# Altium schematic sheet USB_and_FTDI.SchDoc
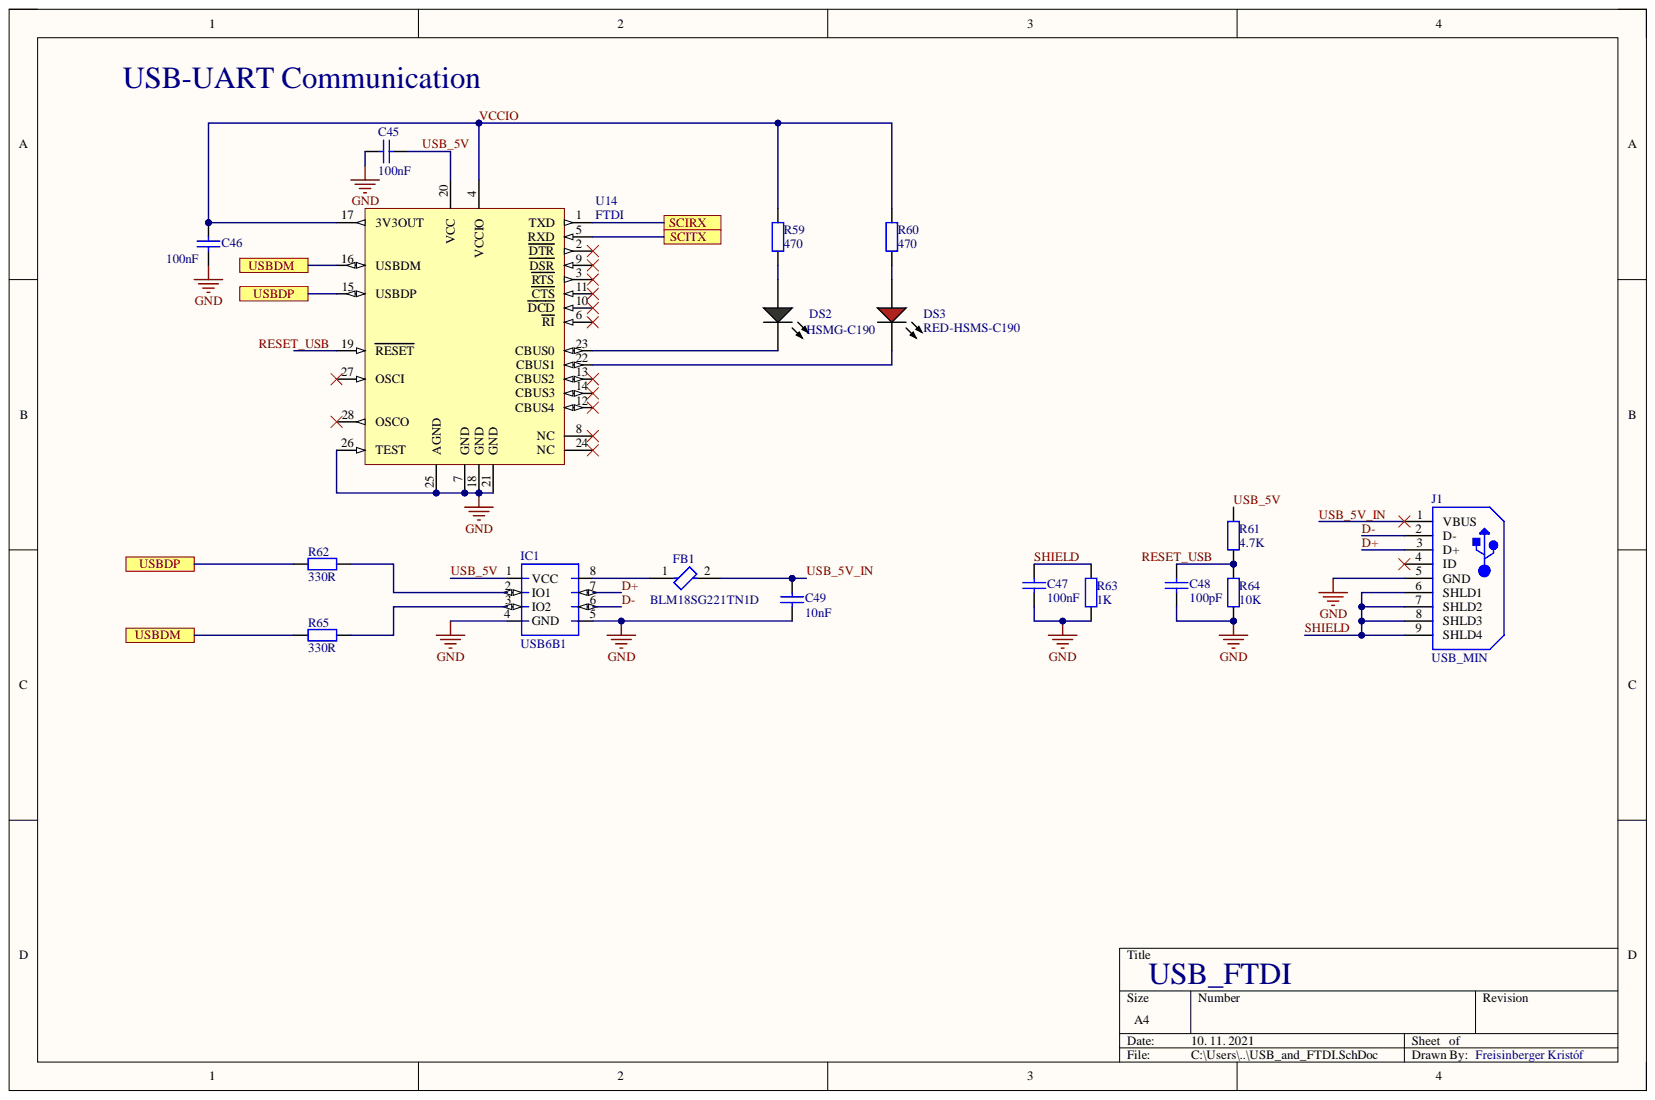
[diagram p0: USB_and_FTDI.SchDoc]

## Parts
C45  100nF  [FP_0805_CAP_085]
C46  100nF  [FP_0805_CAP_085]
C47  100nF  [FP_0805_CAP_085]
C48  100pF  [FP_0805_CAP_085]
C49  10nF  [FP_0805_CAP_085]
DS2  HSMG-C190  [AVAG-HSMX-C190_V]
DS3  RED-HSMS-C190  [AVAG-HSMX-C190_V]
FB1  BLM18SG221TN1D  [FP-BLM18-0_15-t0_5-IPC_A]
IC1  USB6B1  [INF-P-DSO-8-3_M - duplicate1]
J1  USB_MIN  [FCI-10033526-N3212LF_V]
R59  470  [FP_0603_RES]
R60  470  [FP_0603_RES]
R61  4.7K  [FP_0603_RES]
R62  330R  [FP_0603_RES]
R63  1K  [FP_0603_RES]
R64  10K  [FP_0603_RES]
R65  330R  [FP_0603_RES]
U14  FTDI  [SSOP-28_N]

## Ports
SCIRX, SCITX, USBDM, USBDP

## Nets
GND: C45.1 C46.1 C47.1 C48.1 C49.1 IC1.4(GND) IC1.5 J1.5(GND) R63.1 R64.1 U14.7(GND) U14.18(GND) U14.21(GND) U14.25(AGND) U14.26(TEST)
D+: IC1.7 J1.3(D+)
D-: IC1.6 J1.2(D-)
RESET_USB: C48.2 R61.1 R64.2 U14.19(RESET)
SCIRX: U14.1(TXD) ; port SCIRX
SCITX: U14.5(RXD) ; port SCITX
SHIELD: C47.2 J1.6(SHLD1) J1.7(SHLD2) J1.8(SHLD3) J1.9(SHLD4) R63.2
USB_5V: C45.2 IC1.1(VCC) R61.2 U14.20(VCC)
USB_5V_IN: C49.2 FB1.2 J1.1(VBUS)
USBDM: R65.1 U14.16(USBDM) ; port USBDM
USBDP: R62.1 U14.15(USBDP) ; port USBDP
VCCIO: C46.2 R59.2 R60.2 U14.4(VCCIO) U14.17(3V3OUT)
NetDS2_1: DS2.1 R59.1
NetDS2_2: DS2.2 U14.23(CBUS0)
NetDS3_1: DS3.1 R60.1
NetDS3_2: DS3.2 U14.22(CBUS1)
NetFB1_1: FB1.1 IC1.8
NetIC1_2: IC1.2(IO1) R62.2
NetIC1_3: IC1.3(IO2) R65.2

Not connected: J1 4(ID); U14 2(DTR) 3(RTS) 6(RI) 8(NC) 9(DSR) 10(DCD) 11(CTS) 12(CBUS4) 13(CBUS2) 14(CBUS3) 24(NC) 27(OSCI) 28(OSCO)

## Library data of the parts
C45-C49 = CAP: Capacitor; Component Kind: Standard; Library Name: DCDC_Converter_4_Channel.SchLib
  C45-C47: Value: 100nF
  C48: Value: 100pF
  C49: Value: 10nF
DS2 = LED_G_CMP-1744-00002-1: Chip LED, Green, 2.2 V, -40 to 85 degC, 2-Pin SMD, RoHS, Tape and Reel; Access Time: 3500 ns; Case\Package: SOIC; Clock Frequency: 100 KHz; Density: 32 kb; Frequency: 400 KHz; Interface: Serial; Max Supply Voltage: 5.5 V; Min Supply Voltage: 1.7 V; Nominal Supply Current: 2 mA; Component Kind: Standard; ComponentLink1Description: Manufacturer URL; ComponentLink1URL: http://www.avagotech.com/; ComponentLink2Description: Datasheet; ComponentLink2URL: http://docs.avagotech.com/docs/AV02-0551EN; ComponentLink3Description: Package Specification; ComponentLink3URL: http://www.fairchildsemi.com/dwg/MA/MA03D.pdf; DatasheetVersion: Mar-12; Height: 1.5 mm; Length: 5 mm; Library Name: DCDC_Converter_4_Channel.SchLib; Max Clock Frequency: 100 KHz; Max Operating Temperature: 85 degC; Min Operating Temperature: -40 degC; Mount: Surface Mount; Package Quantity: 3000; PackageDescription: 2-Pin Surface Mount Device, Body 1.6 x 0.8 mm, Height 0.9 mm; PackageReference: HSMX-C190; Packaging: Tape and Reel; Pins: 8; Radiation Hardening: No; REACH SVHC: No SVHC; RoHSCompliant: true; Width: 4 mm
DS3 = RED-CMP-2000-06427-1: LED Uni-Color Red, 52 mW, 20 mA, -40 to 85 degC, 2-Pin SMD, RoHS, Tape and Reel; Manufacturer: Avago; Chip Material: GaP; DELETED: Compliant; Dominant Wave Length: 626nm; Forward Current: 25mA; Forward Voltage: 2.6V; High Brightness: No; Lens Type: ColorlessDiffused; Lum Intensity: 10mcd; Main Category: ChipLED; Number of  Elements: 1; Peak Wavelength: 630nm; Power Dissipation: 52W; Product Depth (mm): 0.8mm; Product Length (mm): 1.6mm; Reverse Voltage: 5V; Shape Type: Rectangular; Test Current (It): 20mA; Viewing Angle: 170deg; Component Kind: Standard; Datasheet URL: http://docs.avagotech.com/docs/AV02-0551EN; Datasheet Version: 03/2012; Emitting Color: Red; Lens Dimensions: 1x0.8x0.5mm; Library Name: DCDC_Converter_4_Channel.SchLib; Luminous Intensity: 10mcd; Manufacturer URL: http://www.avagotech.com/; Mounting: SurfaceMount; Mounting Technology: SurfaceMount; Operating Temp Range: -40Cto85C; Operating Temperature Classification: Industrial; Package Description: 2-Pin Surface Mount Device, Body 1.6 x 0.8 mm, Height 0.9 mm; Package Reference: HSMX-C190; Package Type: ChipLed; Packaging: TapeandReel; Pin Count: 2; Product Height (mm): 0.8mm; Rad Hardened: No; Type: Uni-Color
FB1 = CMP-0686-00596-3: Chip Ferrite Bead, 0603, 220Ω @ 100MHz, 25%, 0.04Ω, 2.5A; DC Resistance (DCR): 40mR; Impedance: 220R; Number of Circuits: 1; Case Code (Imperial): 0603; Case Code (Metric): 1608; Case/Package: 0603; Component Kind: Standard; Core Material: Ferrite; Current Rating: 2.5A; DC Current: 2.5A; DC Resistance-Max: 40mR; Depth: 0.95mm; Height: 0.8mm; Lead Free: Lead Free; Length: 1.6mm; Library Name: Altium Content Vault; Material: Ferrite; Max Current Rating: 2.5A; Max DC Current: 2.5A; Max Operating Temperature: 125°C; Min Operating Temperature: -55°C; Number of Lines: 1; Number of Pins: 2; Package Quantity: 1; Packaging: Tape and Reel; Radiation Hardening: No; REACH SVHC: No SVHC; RoHS Compliant: Yes; Series: BLM18S; Termination: SMD/SMT; Test Frequency: 100MHz; Tolerance: 25%; Weight: 7.1E-05oz; Width: 0.8mm
IC1 = USB6B1: Clamp Ipp Tvs Diode Surface Mount 8-SO; MF: STMicroelectronics; Availability: Unavailable; Component Kind: Standard; Library Name: DCDC_Converter_4_Channel.SchLib; MP: USB6B1; Package: SOIC-8 STMicroelectronics; Price: None; SnapEDA_Link: https://www.snapeda.com/parts/USB6B1/STMicroelectronics/view-part/51146/?ref=snap
J1 = USB_MIN_CMP-1698-00001-3: Mini USB B-Type, 5-Pin SMD, RoHS, Tape and Reel  10033526-N3212LF; Component Kind: Standard; ComponentLink1Description: Manufacturer URL; ComponentLink1URL: http://portal.fciconnect.com/; ComponentLink2Description: Datasheet; ComponentLink2URL: http://portal.fciconnect.com/Comergent//fci/drawing/10033526.pdf; DatasheetVersion: Rev. N; Height: 4 mm; Length: 9.9 mm; Library Name: DCDC_Converter_4_Channel.SchLib; Mount: Surface Mount; Mounting Technology: Surface Mount; Package Quantity: 1; PackageDescription: 5-Pin Surface Mount Device, Body 9.2 x 7.7 mm, Pitch 0.8 mm; Packaging: Tape and Reel; PartNumber: 10033526-N3212LF; Pins: 5; RoHSCompliant: True
R59-R65 = RES: Resistor; Component Kind: Standard; Library Name: DCDC_Converter_4_Channel.SchLib
  R59 R60: Value: 470
  R61: Value: 4.7K
  R62 R65: Value: 330R
  R63: Value: 1K
  R64: Value: 10K
U14 = FTDI-CMP28-0078-00029-3: USB UART Asynchronous Serial Data Transfer Chip, 28-pin SSOP, Tape and Reel; PartNumber: FT232RL-Reel; Component Kind: Standard; ComponentLink1Description: Manufacturer URL; ComponentLink1URL: http://www.ftdichip.com/; ComponentLink2Description: Datasheet; ComponentLink2URL: http://www.ftdichip.com/Support/Documents/DataSheets/ICs/DS_FT232R.pdf; DatasheetVersion: ver 2.10, Mar-2012; Library Name: DCDC_Converter_4_Channel.SchLib; Manufacturer: FTDI; PackageDescription: 28-Pin Small Outline Package 0.65 mm Pitch; PackageReference: SSOP-28; PackageVersion: Mar-12; RevisionNote: DatasheetVersion updated to 2.10 Mar-2012
